# Altium schematic sheet Sheet1.SchDoc
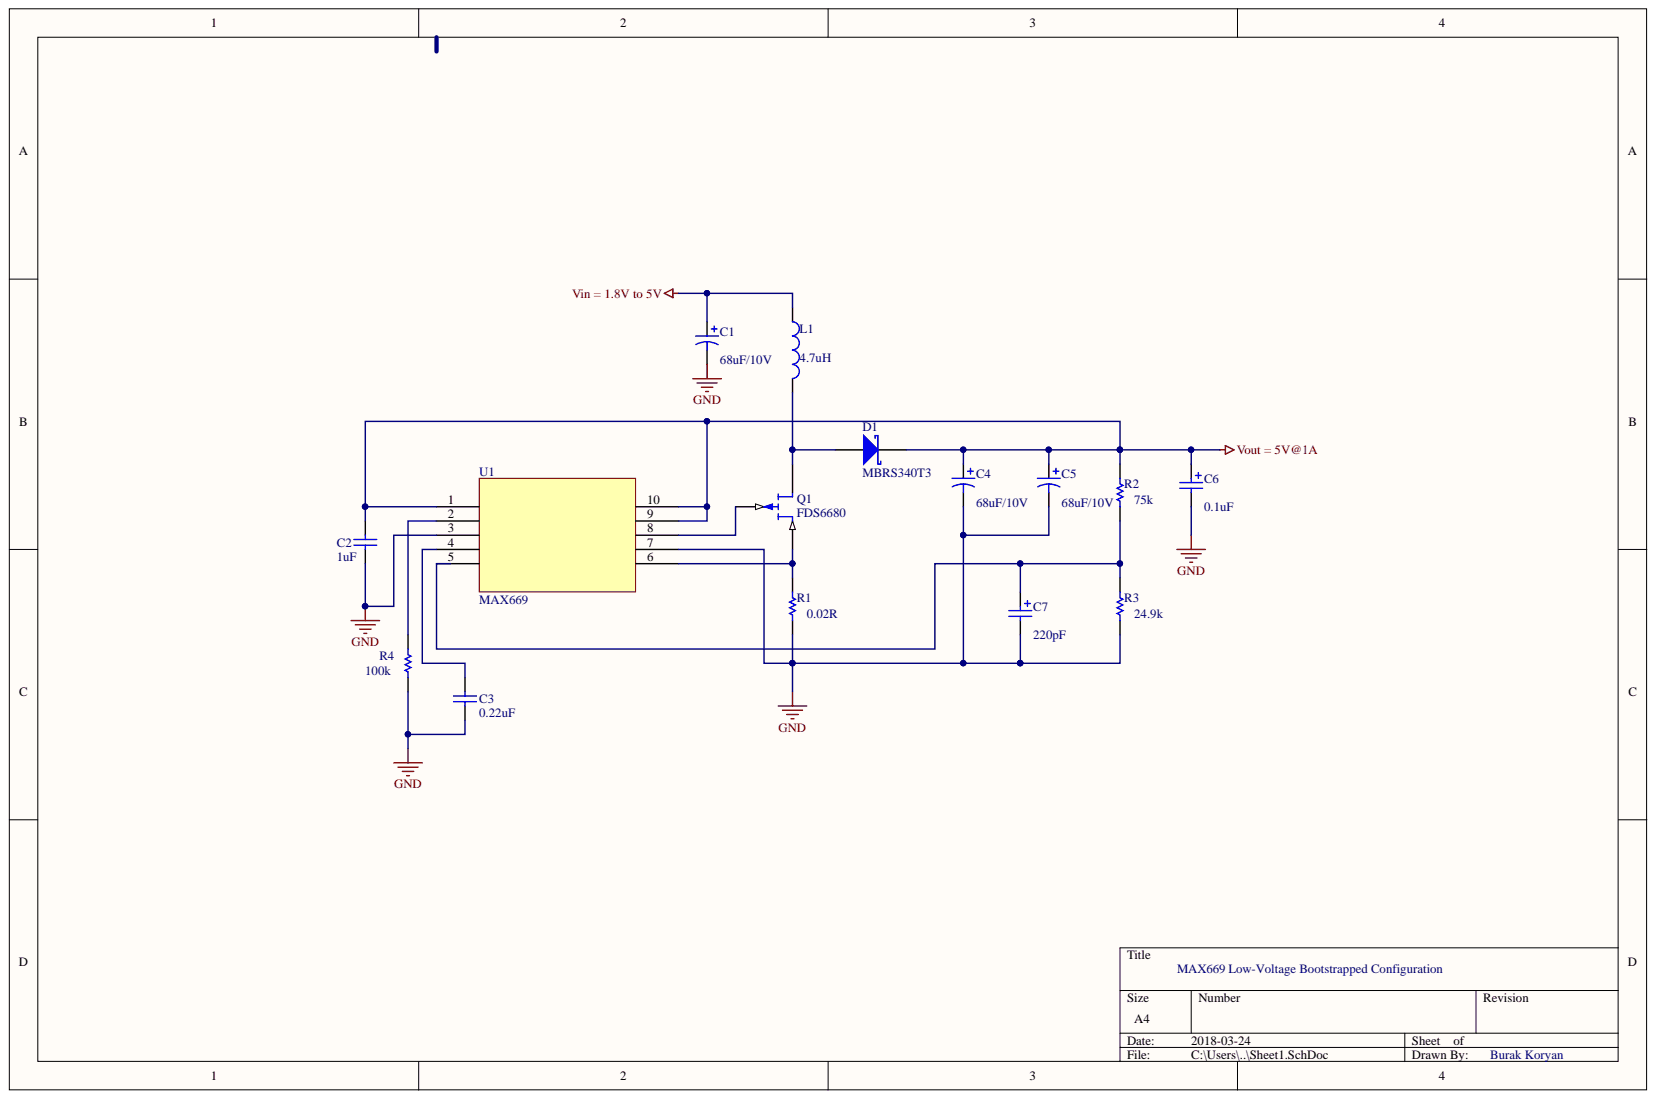
[diagram p0: Sheet1.SchDoc]

## Parts
C1  value 68uF/10V  [RB7.6-15]
C2  value 1uF  [C1206]  (hidden comment: Cap Semi)
C3  value 0.22uF  [C1206]  (hidden comment: Cap Semi)
C4  value 68uF/10V  [RB7.6-15]
C5  value 68uF/10V  [RB7.6-15]
C6  value 0.1uF  [POLAR0.8]
C7  value 220pF  [POLAR0.8]
D1  MBRS340T3  [TO-220AC]
L1  value 4.7uH  [0402-A]
Q1  FDS6680  [TO-18]
R1  value 0.02R  [AXIAL-0.5]
R2  value 75k  [AXIAL-0.5]
R3  value 24.9k  [AXIAL-0.5]
R4  value 100k  [AXIAL-0.5]
U1  MAX669

## Nets
GND: C1.2 C2.1 C3.1 C4.2 C5.2 C6.2 C7.2 R1.1 R3.1 R4.1 U1.7
Vin = 1.8V to 5V: C1.1 L1.1
Vout = 5V@1A: C2.2 C4.1 C5.1 C6.1 D1.1 R2.2 U1.9 U1.10
NetC7_1: C7.1 R2.1 R3.2
NetD1_3: D1.3 L1.2 Q1.3
NetQ1_1: Q1.1 R1.2 U1.6
NetQ1_2: Q1.2 U1.8
N00002:

Not connected: C3 2; R4 2; U1 1 2 3 4 5

## Library data of the parts
C1 C4 C5 = Cap Pol1: Polarized Capacitor (Radial); Component Kind: Standard; LatestRevisionDate: 17-Jul-2002; LatestRevisionNote: Re-released for DXP Platform.; Library Name: Miscellaneous Devices.IntLib; PackageDescription: Polarized Capacitor (Radial); 2 Leads; PackageReference: RB7.6-15; Published: 8-Jun-2000; Signal Integrity: Cap Pol; Simulation: CAP; Publisher: Altium Limited
C2 C3 = Cap Semi: Capacitor (Semiconductor SIM Model); Component Kind: Standard; LatestRevisionDate: 29-May-2009; LatestRevisionNote: IPC-7351 Footprint Added.; Library Name: Miscellaneous Devices.IntLib; PackageDescription: Chip Capacitor; PackageReference: C1206; Published: 8-Jun-2000; Signal Integrity: Cap Semi; Simulation: CAP; Publisher: Altium Limited
C6 C7 = Cap Pol2: Polarized Capacitor (Axial); Component Kind: Standard; LatestRevisionDate: 17-Jul-2002; LatestRevisionNote: Re-released for DXP Platform.; Library Name: Miscellaneous Devices.IntLib; PackageDescription: Polarized Capacitor; 2 Leads; PackageReference: POLAR0.8; Published: 8-Jun-2000; Signal Integrity: Cap Pol; Simulation: CAP; Publisher: Altium Limited
D1 = Diode 10TQ040: Schottky Rectifier; Note: Terminal 2 is the TAB and is electrically connected to the cathode; Component Kind: Standard; ComponentLink1Description: Manufacturer Link; ComponentLink1URL: http://www.irf.com/; DatasheetVersion: PD-2.057B; LatestRevisionDate: 17-Jul-2002; LatestRevisionNote: Re-released for DXP Platform.; Library Name: Miscellaneous Devices.IntLib; PackageDescription: TO, Thru-Hole, Vertical, Heatsink Mounted; 3 In-Line Leads (1 Absent); Pitch 2.54 mm; PackageReference: TO-220AC; PackageVersion: Feb-1998; Published: 8-Jun-2000; Simulation: 10TQ040; Publisher: Altium Limited
L1 = Inductor: Code_IEC: 1005; Code_JEITA: 0402; Component Kind: Standard; Description: Inductor; LatestRevisionDate: 29-May-2009; LatestRevisionNote: IPC-7351 Footprint Added.; Library Name: Miscellaneous Devices.IntLib; PackageDescription: Chip Inductor; PackageReference: 0402-A; Published: 8-Jun-2000; Signal Integrity: Inductor; Simulation: INDUCTOR; Publisher: Altium Limited
Q1 = MESFET-P: P-Channel MESFET; Code_JEDEC: TO-18; Component Kind: Standard; LatestRevisionDate: 17-Jul-2002; LatestRevisionNote: Re-released for DXP Platform.; Library Name: Miscellaneous Devices.IntLib; PackageDescription: Can; 3 Leads; Pin Circle Dia. 2.54 mm; Lead Dia. 0.48 mm (max); PackageReference: TO-18; Published: 8-Jun-2000; Simulation: PMESFET; Publisher: Altium Limited
R1-R4 = Res Semi: Semiconductor Resistor; Component Kind: Standard; LatestRevisionDate: 17-Jul-2002; LatestRevisionNote: Re-released for DXP Platform.; Library Name: Miscellaneous Devices.IntLib; PackageDescription: Axial Device, Thru-Hole; 2 Leads; 0.5 in Pin Spacing; PackageReference: AXIAL-0.5; Published: 8-Jun-2000; Signal Integrity: Res Semi; Simulation: RES; Publisher: Altium Limited
U1 = Component_1: Component Kind: Standard; Library Name: Schlib1.SchLib
